# Altium schematic sheet RIP_AFE.SchDoc
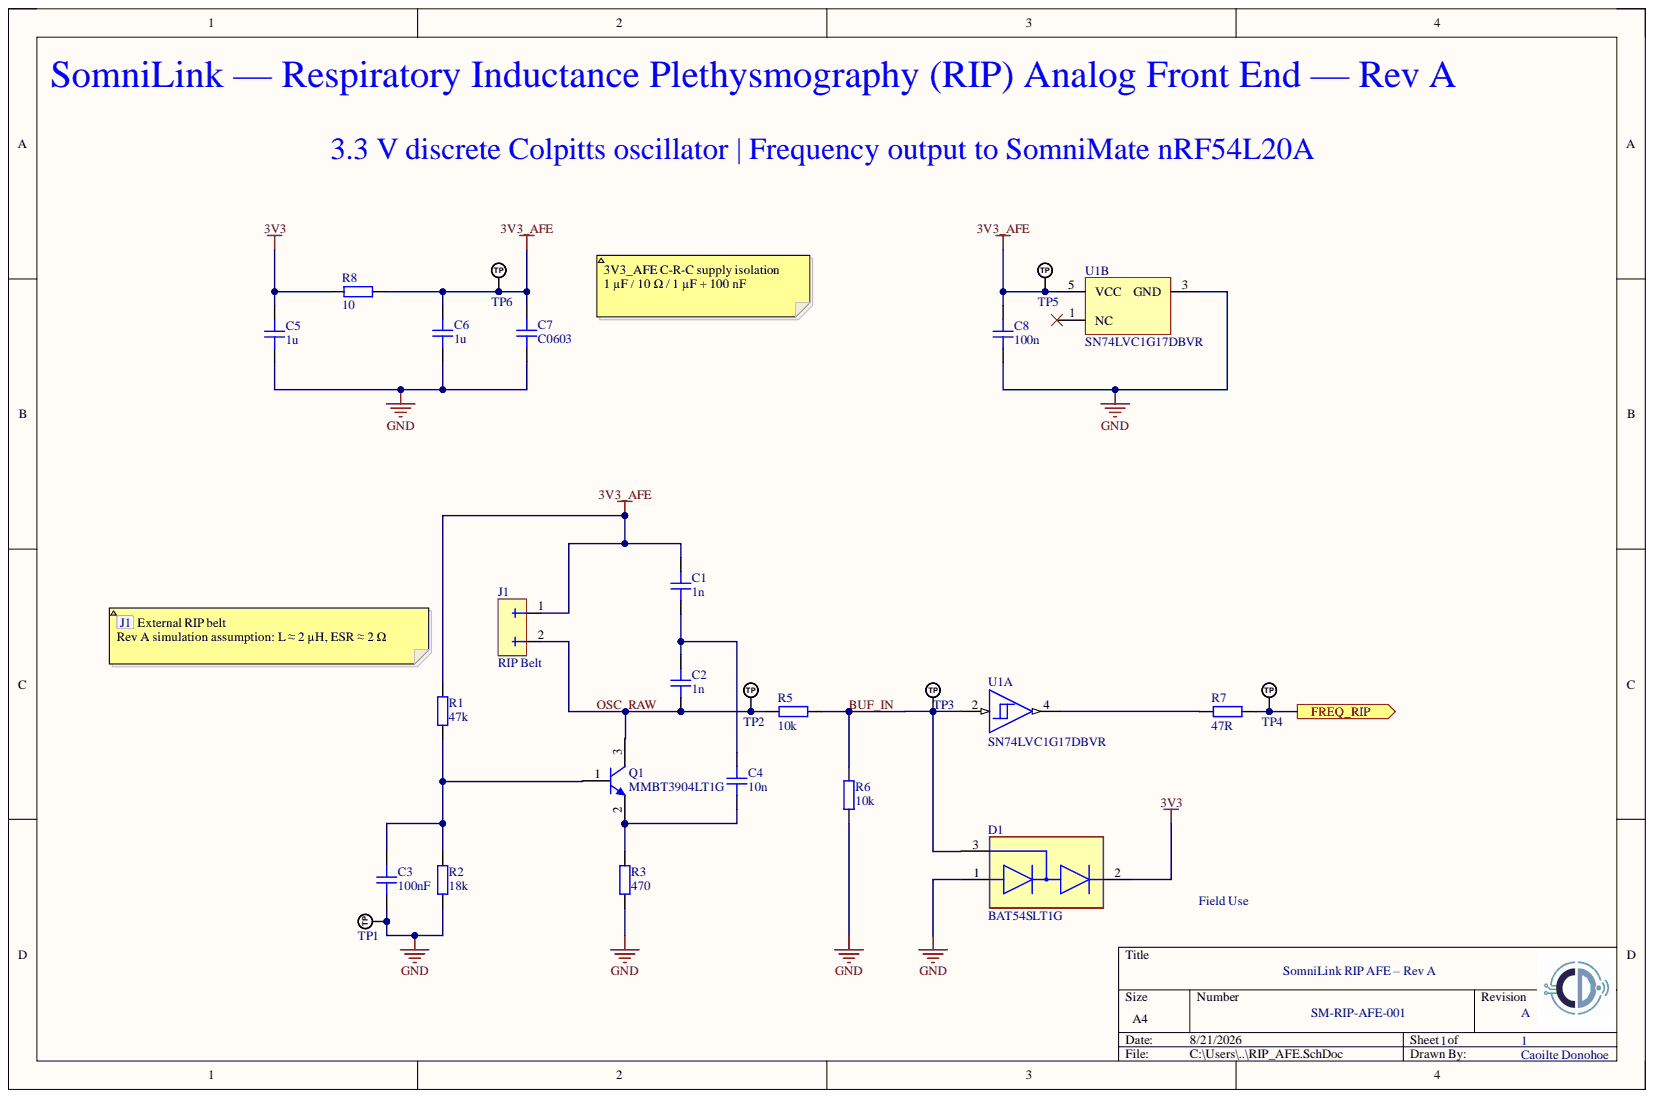
[diagram p0: RIP_AFE.SchDoc]

## Parts
C1  1n  [CAP_0603]
C2  1n  [CAP_0603]
C3  100nF  [CAP_0603]
C4  10n  [CAP_0603]
C5  1u  [CAP_0603]
C6  1u  [CAP_0603]
C7  C0603  [CAP_0603]
C8  100n  [CAP_0603]
D1  BAT54SLT1G  [FP-318-08-MFG]
J1  RIP Belt
Q1  MMBT3904LT1G  [ONSC-SOT-23-3-318-08_V]
R1  47k  [RES_0603]
R2  18k  [RES_0603]
R3  470  [RES_0603]
R5  10k  [RES_0603]
R6  10k  [RES_0603]
R7  47R  [RES_0603]
R8  10  [RES_0603]
TP1  TP_NOBOM  [PAD_RND_1MM]  (value not drawn)  (no BOM)
TP2  TP_NOBOM  [PAD_RND_1MM]  (value not drawn)  (no BOM)
TP3  TP_NOBOM  [PAD_RND_1MM]  (value not drawn)  (no BOM)
TP4  TP_NOBOM  [PAD_RND_1MM]  (value not drawn)  (no BOM)
TP5  TP_NOBOM  [PAD_RND_1MM]  (value not drawn)  (no BOM)
TP6  TP_NOBOM  [PAD_RND_1MM]  (value not drawn)  (no BOM)
U1  SN74LVC1G17DBVR  [DBV0005A_M]  (parts A,B)

## Ports
FREQ_RIP

## Nets
3V3: C5.2 D1.2 R8.1
3V3_AFE: C1.2 C6.2 C7.2 C8.2 J1.1 R1.2 R8.2 TP5.1 TP6.1 U1.5(VCC)
GND: C3.1 C5.1 C6.1 C7.1 C8.1 D1.1 R2.1 R3.1 R6.1 TP1.1 U1.3(GND)
BUF_IN: R5.1 R6.2 TP3.1 U1.2
FREQ_RIP: R7.2 TP4.1 ; port FREQ_RIP
OSC_RAW: C2.1 J1.2 R5.2 TP2.1
NetC1_1: C1.1 C2.2 C4.2
NetC3_2: C3.2 R1.1 R2.2
NetC4_1: C4.1 Q1.2 R3.2
NetR7_1: R7.1 U1.4

Not connected: D1 3; Q1 1 3; U1 1(NC)

## Library data of the parts
C1-C8 = CAP_0603: Capacitor SMD 0603; Component Kind: Standard; Library Name: Schematic-Lib-PMS_Caoilte.SchLib
D1 = CMP-1055-00536-2: DIODE ARRAY SCHOTTKY 30V SOT23-3; Average Rectified Current: 200mA; Capacitance: 10pF; Element Configuration: Dual; Forward Voltage: 800mV; Max Forward Surge Current (Ifsm): 600mA; Max Reverse Leakage Current: 2uA; Peak Non-Repetitive Surge Current: 1A; Power Dissipation: 225mW; Recovery Time: 5ns; Case/Package: SOT-23-3; Component Kind: Standard; Contact Plating: Tin; Current Rating: 200mA; Forward Current: 200mA; Halogen Free: Halogen Free; Height: 0.94mm; Lead Free: Lead Free; Length: 2.9mm; Library Name: BAT54SLT1G.IntLib; Max Operating Temperature: 150°C; Max Repetitive Reverse Voltage (Vrrm): 30V; Max Reverse Voltage (DC): 30V; Max Surge Current: 600mA; Min Operating Temperature: -55°C; Number of Pins: 3; Package Quantity: 3000; Packaging: Tape and Reel; Peak Reverse Current: 2uA; Radiation Hardening: No; REACH SVHC: No SVHC; Reverse Recovery Time: 5ns; Voltage Rating (DC): 30V; Width: 1.3mm
J1 = CONN_2PIN_GENERIC: Generic 2-Pin RIP Belt Interface; Component Kind: Standard; Library Name: Schematic-Lib-PMS_Caoilte.SchLib
Q1 = CMP-1048-00631-1: General Purpose Transistor, NPN Silicon, 3-Pin SOT-23, Pb-Free, Tape and Reel; Manufacturer: On Semiconductor; fT Min (MHz): 300; IC Continuous (A): 0.2; Component Kind: Standard; ComponentLink1Description: Manufacturer URL; ComponentLink1URL: http://www.onsemi.com/; ComponentLink2Description: Datasheet; ComponentLink2URL: http://www.onsemi.cn/pub_link/Collateral/MMBT3904LT1-D.PDF; ComponentLink3Description: Package Specification; ComponentLink3URL: http://www.onsemi.cn/pub_link/Collateral/318-08.PDF; DatasheetVersion: Rev. 12; Library Name: MMBT3904LT1G.IntLib; Mounting Technology: Surface Mount; PackageDescription: 3-Pin Small Outline Transistor, Body 2.92 x 1.3 mm, Pitch 0.95 mm; PackageReference: SOT-23-3-318-08; PackageVersion: Rev. AP, 11/2009; Packing: Tape and Reel; PartNumber: MMBT3904LT1G; Polarity: NPN; RoHS: TRUE
R1-R3 R5-R8 = RES_0603: Resistor SMD 0603; Component Kind: Standard; Library Name: Schematic-Lib-PMS_Caoilte.SchLib
TP1-TP6 = TEST_POINT_RND_1MM: Test Point Round 1mm (NO BOM); Library Name: Schematic-Lib-PMS_Caoilte.SchLib
U1 = CMP-0859-00033-4: Single Schmitt-Trigger Buffer, DBV0005A, LARGE T&R; Manufacturer: Texas Instruments; 3-State Output: No; Bits(#): 1; F @ Nom Voltage(Max)(Mhz): 150; ICC @ Nom Voltage(Max)(mA): 0.01; Input Type: CMOS/TTL; Logic: True; Output Drive (IOL/IOH)(Max)(mA): 32/-32; Output Type: CMOS; Rating: Catalog; Schmitt Trigger: Yes; Sub-Family: Non-Inverting Buffer/Driver; Technology Family: LVC; tpd @ Nom Voltage(Max)(ns): 11,6.5,5.5,5; VCC(Max)(V): 5.5; VCC(Min)(V): 1.65; Voltage(Nom)(V): 1.8,2.5,3.3,5; Component Kind: Standard; DatasheetVersion: SCES351V; Generic Part Number: SN74LVC1G17; Library Name: SN74LVC1G17DBVR.IntLib; Operating Temperature Range(C): -40 to 125,-40 to 85; PackageReference: DBV0005A
